# Altium schematic sheet Ardu_gen_eeprom.SchDoc
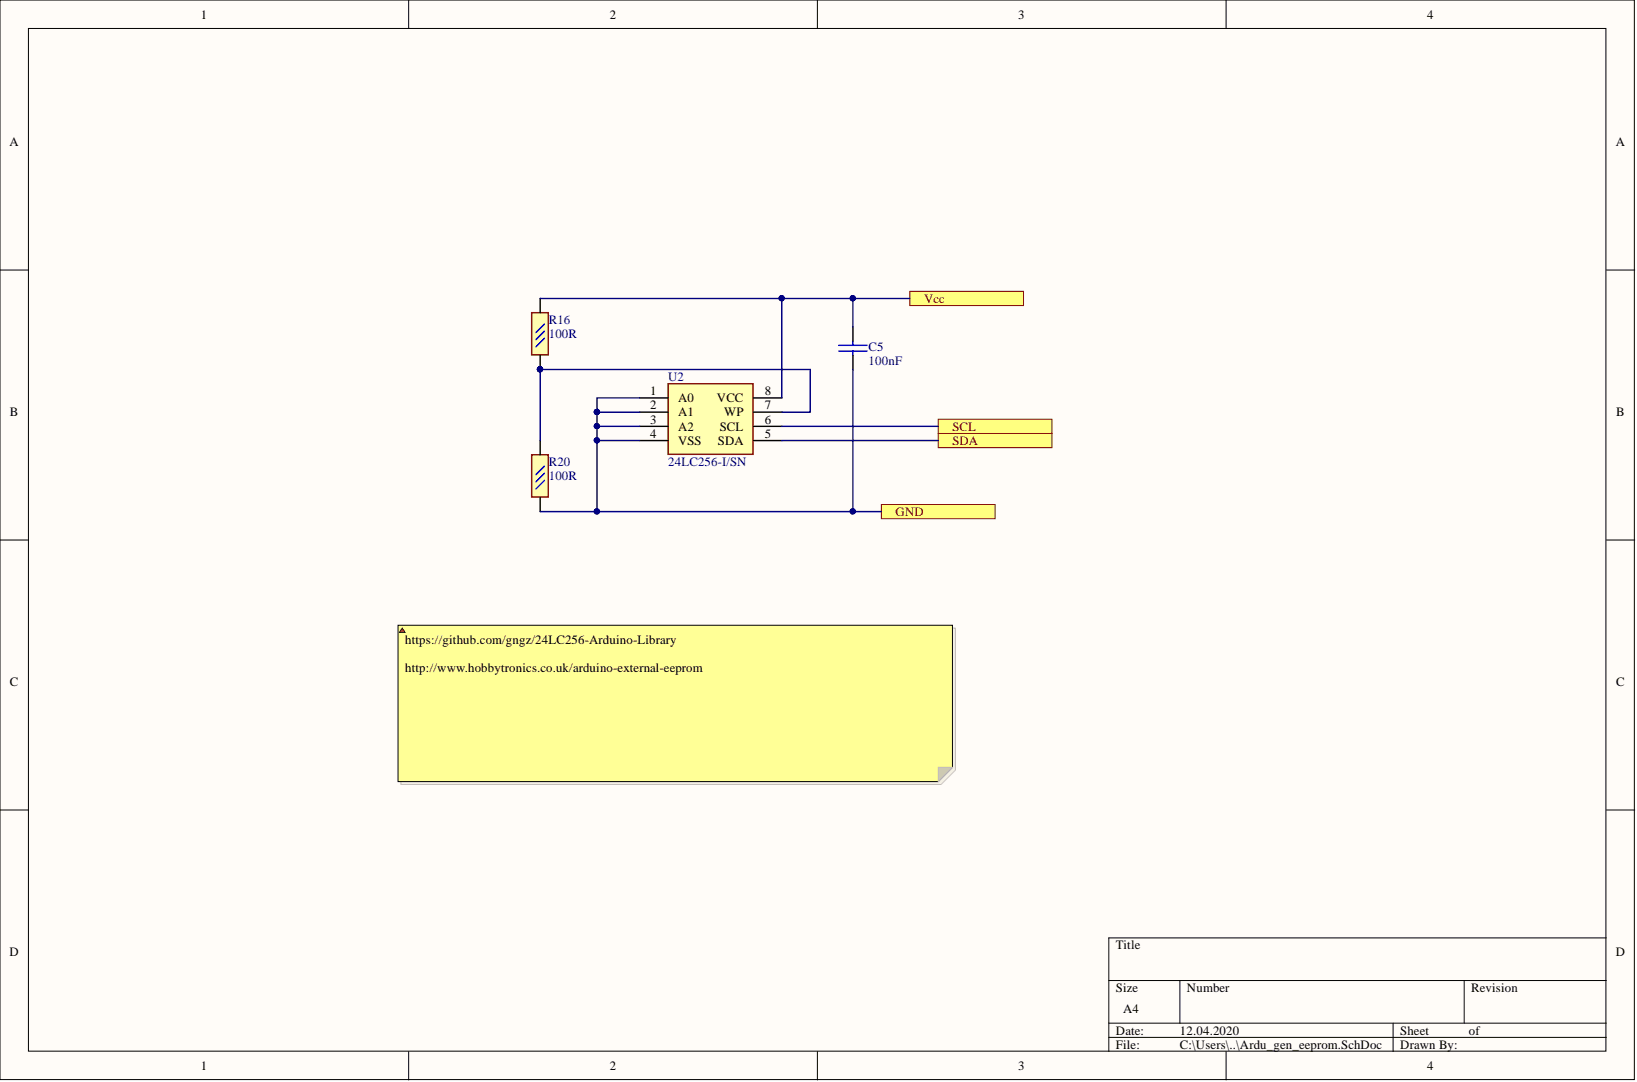
[diagram p0: Ardu_gen_eeprom.SchDoc]

## Parts
C5  100nF  [0603c]
R16  100R  [0603r]
R20  100R  [0603r]
U2  24LC256-I/SN  [SO8_P1.27_W3.9_L4.90]

## Ports
GND, SCL, SDA, Vcc

## Nets
GND: C5.2 R20.1 U2.1(A0) U2.2(A1) U2.3(A2) U2.4(VSS) ; port GND
SCL: U2.6(SCL) ; port SCL
SDA: U2.5(SDA) ; port SDA
Vcc: C5.1 R16.2 U2.8(VCC) ; port Vcc
NetR16_1: R16.1 R20.2 U2.7(WP)

## Library data of the parts
C5 = 100nF 25V 0603: Company: Yageo; ID: B1153B11114; Order Code: CC0603KRX7R8BB104; TSKD: FS-CM_StandDet_Capacitor_CeramicSMD(100nF_25_10%_0603_X7R); Type: Capacitor; Component Kind: Standard; Description: 100nF 25V 0603; Supplier 1: Digi-Key; Supplier Part Number 1: 311-1341-1-ND; Value: 100nF; Library Name: CAPACITOR_dropbox.SchLib
R16 R20 = 100R 0603 1%: Company: Yageo; ID: B112CV1117V; Order Code: RC0603FR-07100RL; TSKD: FS-CM_StandDet_Resistor_SMD(100R_1%_100_0603); Component Kind: Standard; Description: 100R 0603 1%; Library Name: RESISTORs_Home.SchLib; Supplier 1: Digi-Key; Supplier Part Number 1: 311-100HRCT-ND; Type: Resistor; Value: 100R
U2 = 24LC256-I/SN: 256 Kb I2C 2 Wire (32K X 8) 2.5 V Serial EEPROM SMT - SOIC-8; Allied_Number: 70414275; Company: Microchip; Allied Price/Stock: http://www.alliedelec.com/microchip-technology-inc-24lc256-e-sn/70414275/; Component Kind: Standard; ComponentLink1Description: pdf; ComponentLink1URL: http://ww1.microchip.com/downloads/en/devicedoc/21203m.pdf; Datasheet Link: http://ww1.microchip.com/downloads/en/DeviceDoc/20001203U.pdf; Height: 1.75mm; Mouser Part Number: 579-24LC256-E/SN; Mouser Price/Stock: https://www.mouser.com/Search/Refine.aspx?Keyword=579-24LC256-E%2FSN; Order Code: 24LC256-I/SN; RS Price/Stock: http://uk.rs-online.com/web/p/products/6678246; Supplier 1: Digi-Key; Supplier Part Number 1: 24LC256-I/SN-ND; Type: IC; Library Name: INTEGRSCH_Home.SchLib
